# Altium schematic sheet Sensor.SchDoc(Sensor)
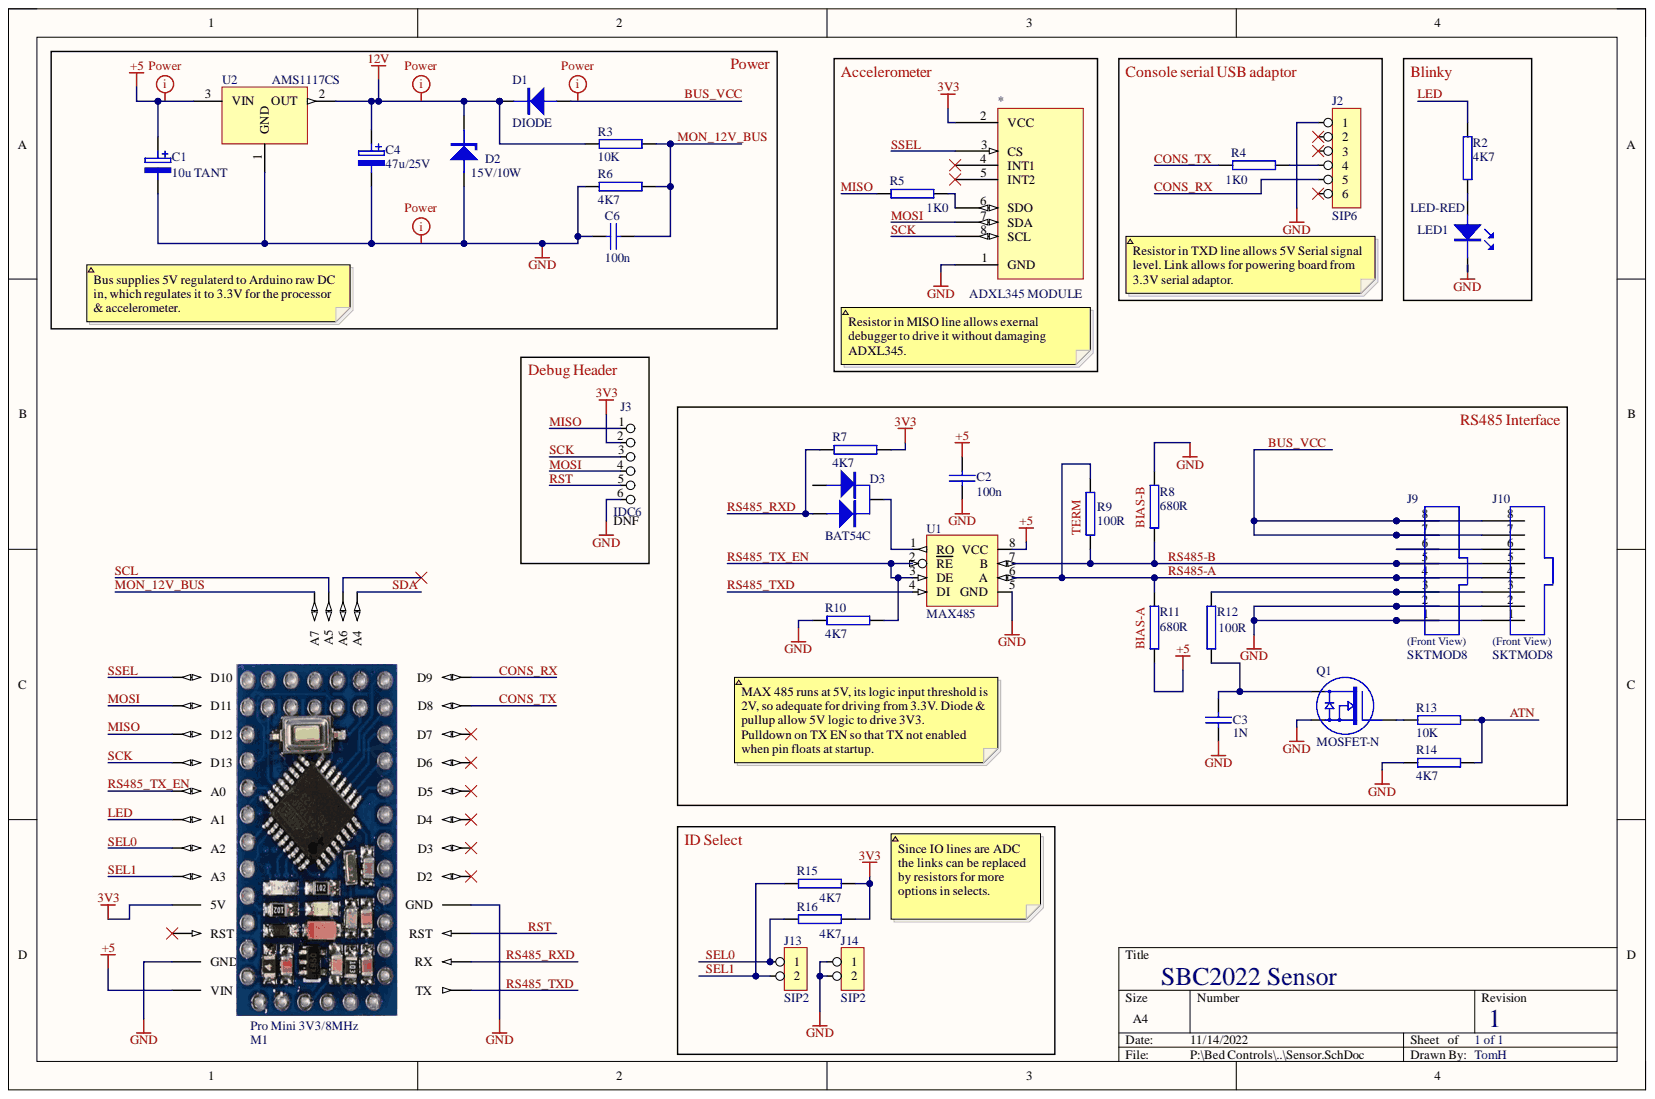
[diagram p0: Sensor.SchDoc]

## Parts
*  ADXL345 MODULE  [ADXL345_MODULE]
C1  10u TANT  [TC6032-2412]
C2  100n  [SMD1206]
C3  1N  [SMD1206]
C4  47u/25V  [RB.1/.2]
C6  100n  [SMD1206]
D1  DIODE  [DO-214]
D2  15V/10W  [DIODE0.6]
D3  BAT54C  [SOT-23]
J2  SIP6  [SIP6]
J3  IDC6  [IDC6]
J9  SKTMOD8  [SKTMOD8PCB]
J10  SKTMOD8  [SKTMOD8PCB]
J13  SIP2  [SIP2]
J14  SIP2  [SIP2]
LED1  LED-RED  [LED]
M1  Pro Mini 3V3/8MHz  [ArduinoMiniPro4]
Q1  MOSFET-N  [SOT-23]
R2  4K7  [SMD1206]
R3  10K  [SMD1206]
R4  1K0  [SMD1206]
R5  1K0  [SMD1206]
R6  4K7  [SMD1206]
R7  4K7  [SMD1206]
R8  680R  [SMD1206]
R9  100R  [SMD1206]
R10  4K7  [SMD1206]
R11  680R  [SMD1206]
R12  100R  [SMD1206]
R13  10K  [SMD1206]
R14  4K7  [SMD1206]
R15  4K7  [SMD1206]
R16  4K7  [SMD1206]
U1  MAX485  [SMD8A]
U2  AMS1117CS  [SOT223]

## Nets
3V3: *.2(VCC) J3.2 M1.JP6-4(5V) R7.2 R15.2 R16.2
12V: C4.1 D1.K D2.K R3.1 U2.2
+5: C1.1 C2.2 M1.JP6-1(VIN) R11.1 U1.8(VCC) U2.3
GND: *.1(GND) C1.2 C2.1 C3.1 C4.2 C6.1 D2.A J2.1 J3.6 J9.1 J9.2 J10.1 J10.2 J14.1 J14.2 LED1.K M1.JP6-2(GND) M1.JP7-9(GND) Q1.2 R6.1 R8.2 R10.1 R14.1 U1.5(GND) U2.1
ATN: R13.2 R14.2
BUS_VCC: D1.A J9.7 J9.8 J10.7 J10.8
CONS_RX: J2.5 M1.JP7-1(D9)
CONS_TX: M1.JP7-2(D8) R4.1
LED: M1.JP6-7(A1) R2.2
MISO: J3.1 M1.JP6-10(D12) R5.1
MON_12V_BUS: C6.2 M1.JP3-1(A7) R3.2 R6.2
MOSI: *.7(SDA) J3.4 M1.JP6-11(D11)
RS485-A: J9.4 J10.4 R9.2 R11.2 U1.6(A)
RS485-B: J9.5 J10.5 R8.1 R9.1 U1.7(B)
RS485_RXD: D3.1 M1.JP7-11(RX) R7.1
RS485_TX_EN: M1.JP6-8(A0) R10.2 U1.2(RE) U1.3(DE)
RS485_TXD: M1.JP7-12(TX) U1.4(DI)
RST: J3.5 M1.JP7-10(RST)
SCK: *.8(SCL) J3.3 M1.JP6-9(D13)
SCL: M1.JP2-2(A5)
SDA: M1.JP2-1(A4)
SEL0: J13.1 M1.JP6-6(A2) R16.1
SEL1: J13.2 M1.JP6-5(A3) R15.1
SSEL: *.3(CS) M1.JP6-12(D10)
Net*_6: *.6(SDO) R5.2
NetC3_2: C3.2 Q1.3 R12.1
NetD3_3: D3.3 U1.1(RO)
NetJ2_4: J2.4 R4.2
NetJ9_3: J9.3 J10.3 R12.2
NetJ9_6: J9.6 J10.6
NetLED1_A: LED1.A R2.1
NetQ1_1: Q1.1 R13.1

Not connected: * 4(INT1) 5(INT2); D3 2; J2 2 3 6; M1 JP3-2(A6) JP6-3(RST) JP7-3(D7) JP7-4(D6) JP7-5(D5) JP7-6(D4) JP7-7(D3) JP7-8(D2)

## Library data of the parts
* = ADXL345: Component Kind: Standard; Library Name: v4_Misc.SCHLIB
C1 C4 = ELECTRO: Electrolytic Cap; Component Kind: Standard; Library Name: 2015TslibSch.LIB
C2 C3 C6 = CAP: Non-Polarised Cap; Component Kind: Standard; Library Name: v4_TslibSch.LIB
D2 = ZENER1: Component Kind: Standard; Library Name: v4_Tslib.SCHLIB
D3 = DIODE2CC: Dual Diode Common Cathode; Component Kind: Standard; Library Name: v4_Tslib.SCHLIB
LED1 = LED-3MM-RD: Component Kind: Standard; Library Name: 2015TslibSch.LIB
M1 = ArduinoMiniPro2: Component Kind: Standard; Library Name: v4_MiscSch.LIB
R2-R16 = RES2: Resistor; Component Kind: Standard; Library Name: v4_TslibSch.LIB
U1 = MAX485: RS485 Tranceiver; Component Kind: Standard; Library Name: dxp_DMISC.SchLib
U2 = AMS1117CS: Volt Regulator - 3-11mA quiescent current; Component Kind: Standard; Library Name: dxp_DMISC.SchLib
D1 = DIODE: Component Kind: Standard; Library Name: v4_Tslib.SCHLIB
J2 = SIP6: Component Kind: Standard; Library Name: v4_Tslib.SCHLIB
J3 = IDC6: Component Kind: Standard; Library Name: v4_Tslib.SCHLIB
J9 J10 = SKTMOD8: Component Kind: Standard; Library Name: v4_Tslib.SCHLIB
J13 J14 = SIP2: Component Kind: Standard; Library Name: v4_Tslib.SCHLIB
Q1 = MOSFET-N: Component Kind: Standard; Library Name: v4_Tslib.SCHLIB
